# Altium schematic sheet 03-10715_Rev_0.1_Sheet_4.SchDoc
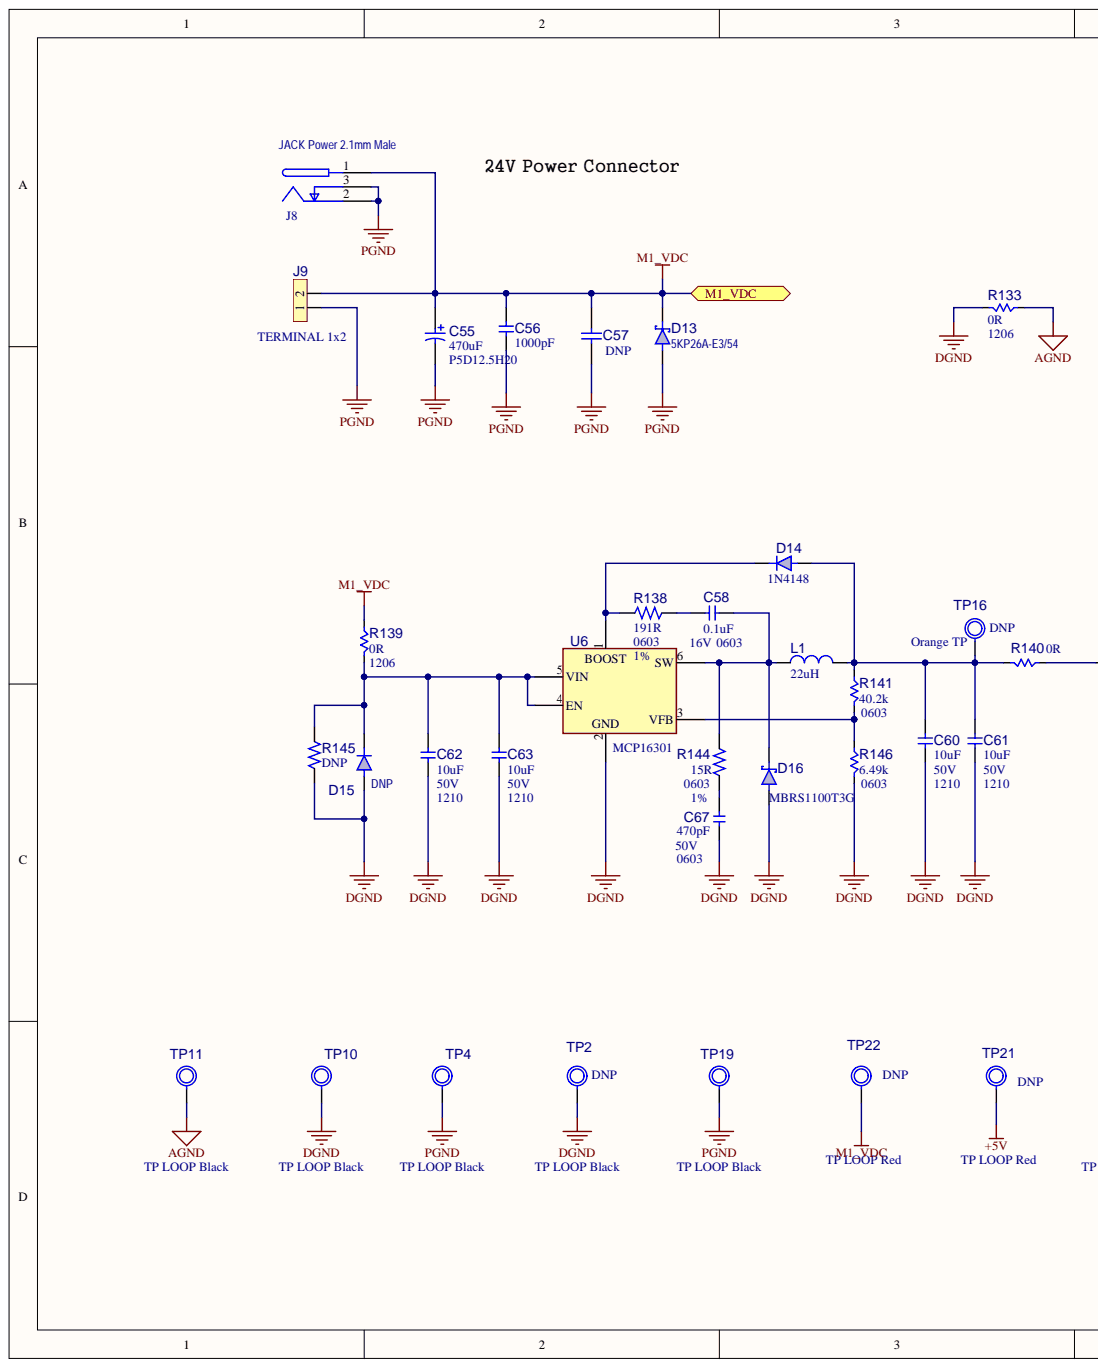
[diagram p0: 03-10715_Rev_0.1_Sheet_4.SchDoc, left]
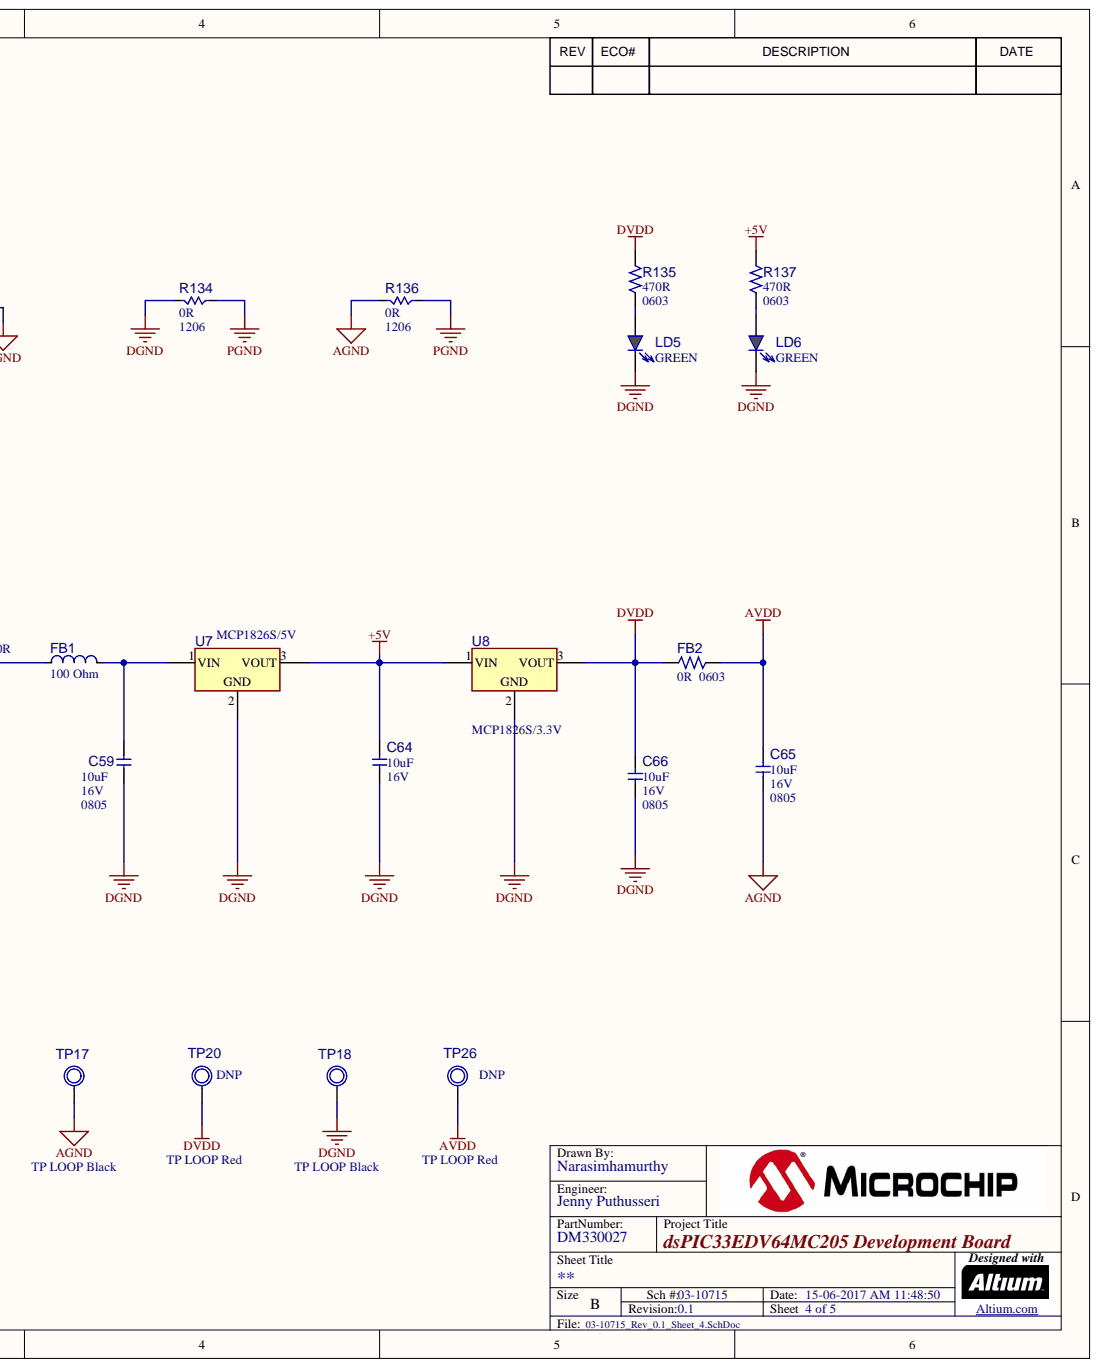
[diagram p1: 03-10715_Rev_0.1_Sheet_4.SchDoc, right]

## Parts
C55  value 470uF  Package: P5D12.5H20  [CAP_RAD_P5D12.5H20]  (hidden comment: Capacitor POL)
C56  value 1000pF  [CAP_C_0603]  (hidden comment: Capacitor NP)
C57  Populated: DNP  [CAP_C_2220]  (hidden comment: Capacitor NP)
C58  value 0.1uF  [CAP_C_0603]  (hidden comment: Capacitor NP)
C59  value 10uF  Package: 0805  Voltage: 16V  [CAP_C_0805]  (hidden comment: Capacitor NP)
C60  value 10uF  Package: 1210  Voltage: 50V  [CAP_C_1210]  (hidden comment: Capacitor NP)
C61  value 10uF  Package: 1210  Voltage: 50V  [CAP_C_1210]  (hidden comment: Capacitor NP)
C62  value 10uF  Package: 1210  Voltage: 50V  [CAP_C_1210]  (hidden comment: Capacitor NP)
C63  value 10uF  Package: 1210  Voltage: 50V  [CAP_C_1210]  (hidden comment: Capacitor NP)
C64  value 10uF  Voltage: 16V  [CAP_C_0805]  (hidden comment: Capacitor NP)
C65  value 10uF  Package: 0805  Voltage: 16V  [CAP_C_0805]  (hidden comment: Capacitor NP)
C66  value 10uF  Package: 0805  Voltage: 16V  [CAP_C_0805]  (hidden comment: Capacitor NP)
C67  value 470pF  Package: 0603  Voltage: 50V  [CAP_C_0603]  (hidden comment: Capacitor NP)
D13  value 5KP26A-E3/54  Manufacturer Part Number 1: 5KP26A-E3/54  [DO-P600, Axial]  (hidden comment: Diode Schottky)
D14  value 1N4148  [SOD-323]  (hidden comment: Diode Single)
D15  Populated: DNP  [DO-214AC_SMA]  (hidden comment: Diode Single)
D16  value MBRS1100T3G  Manufacturer Part Number 1: MBRS1100T3G  [DO-214AA_SMB]  (hidden comment: Diode Schottky)
FB1  value 100 Ohm  [IND_C_0603]  (hidden comment: Inductor)
FB2  value 0R  Package: 0603  [RES_C_0603]  (hidden comment: Resistor)
J8  value JACK Power 2.1mm Male  [CON_JACK_POWER_TH_RA_CUI_PJ-002]  (hidden comment: CON JACK 2C 3P SPST)
J9  value TERMINAL 1x2  [CON_TERMINAL_5.08_1x2_TH_RA_OST_OSTVI022152]  (hidden comment: Header 1x2)
L1  value 22uH  [IND_CFT_XAL6060_L6.56W6.36H6.1]  (hidden comment: Inductor)
LD5  value GREEN  [LED_0603_GREEN]  (hidden comment: LED_green)
LD6  value GREEN  [LED_0603_GREEN]  (hidden comment: LED_green)
R133  value 0R  Package: 1206  [RES_C_1206]  (hidden comment: Resistor)
R134  value 0R  Package: 1206  [RES_C_1206]  (hidden comment: Resistor)
R135  value 470R  Package: 0603  [RES_C_0603]  (hidden comment: Resistor)
R136  value 0R  Package: 1206  [RES_C_1206]  (hidden comment: Resistor)
R137  value 470R  Package: 0603  [RES_C_0603]  (hidden comment: Resistor)
R138  value 191R  [RES_C_0603]  (hidden comment: Resistor)
R139  value 0R  Package: 1206  [RES_C_1206]  (hidden comment: Resistor)
R140  value 0R  [RES_C_0603]  (hidden comment: Resistor)
R141  value 40.2k  Package: 0603  [RES_C_0603]  (hidden comment: RES TKF 40.2k 1% 1/10W SMD 0603)
R144  value 15R  Package: 0603  Tolerance: 1%  [RES_C_0603]  (hidden comment: Resistor)
R145  Populated: DNP  [RES_C_0805]  (hidden comment: Resistor)
R146  value 6.49k  Package: 0603  [RES_C_0603]  (hidden comment: Resistor)
TP2  value TP LOOP Black  Populated: DNP  [CON_TP_TH_V_BLK_KEC_5011]  (hidden comment: Test Point)
TP4  value TP LOOP Black  [CON_TP_TH_V_BLK_KEC_5011]  (hidden comment: Test Point)
TP10  value TP LOOP Black  [CON_TP_TH_V_BLK_KEC_5011]  (hidden comment: Test Point)
TP11  value TP LOOP Black  [CON_TP_TH_V_BLK_KEC_5011]  (hidden comment: Test Point)
TP16  value Orange TP  Populated: DNP  [CON_TP_TH_V_ORN_KEC_5013]  (hidden comment: Test Point)
TP17  value TP LOOP Black  [CON_TP_TH_V_BLK_KEC_5011]  (hidden comment: Test Point)
TP18  value TP LOOP Black  [CON_TP_TH_V_BLK_KEC_5011]  (hidden comment: Test Point)
TP19  value TP LOOP Black  [CON_TP_TH_V_BLK_KEC_5011]  (hidden comment: Test Point)
TP20  value TP LOOP Red  Populated: DNP  [CON_TP_TH_V_RED_KEC_5010]  (hidden comment: Test Point)
TP21  value TP LOOP Red  Populated: DNP  [CON_TP_TH_V_RED_KEC_5010]  (hidden comment: Test Point)
TP22  value TP LOOP Red  Populated: DNP  [CON_TP_TH_V_RED_KEC_5010]  (hidden comment: Test Point)
TP26  value TP LOOP Red  Populated: DNP  [CON_TP_TH_V_RED_KEC_5010]  (hidden comment: Test Point)
U6  value MCP16301  [SOT-23-6]  (hidden comment: MCP16301 SOT-23-6)
U7  value MCP1826S/5V  [SOT-223-3]  (hidden comment: MIC39100 SOT-223-3)
U8  value MCP1826S/3.3V  [SOT-223-3]  (hidden comment: MIC39100 SOT-223-3)

## Ports
M1_VDC

## Nets
+5V: C64.1 R137.1 TP21.1 U7.3 U8.1
AGND: C65.2 R133.2 R136.1 TP11.1 TP17.1
AVDD: C65.1 FB2.2 TP26.1
DGND: C59.2 C60.1 C61.1 C62.1 C63.1 C64.2 C66.2 C67.2 D15.1 D16.1 LD5.2 LD6.2 R133.1 R134.1 R145.1 R146.1 TP2.1 TP10.1 TP18.1 U6.2 U7.2 U8.2
DVDD: C66.1 FB2.1 R135.1 TP20.1 U8.3
PGND: C55.2 C56.1 C57.1 D13.1 J8.2 J8.3 J9.1 R134.2 R136.2 TP4.1 TP19.1
M1_VDC: C55.1 C56.2 C57.2 D13.2 J8.1 J9.2 R139.2 TP22.1 ; port M1_VDC
NetC58_1: C58.1 D16.2 L1.1 R144.2 U6.6(1%_SW)
NetC58_2: C58.2 R138.2
NetC59_1: C59.1 FB1.2 U7.1
NetC60_2: C60.2 C61.2 D14.1 L1.2 R140.1 R141.2 TP16.1
NetC62_2: C62.2 C63.2 D15.2 R139.1 R145.2 U6.4(EN) U6.5(VIN)
NetC67_1: C67.1 R144.1
NetD14_2: D14.2 R138.1 U6.1
NetFB1_1: FB1.1 R140.2
NetLD5_1: LD5.1 R135.2
NetLD6_1: LD6.1 R137.2
NetR141_1: R141.1 R146.2 U6.3(VFB)

## Library data of the parts
C55 = CAP1249: Capacitor; Manufacturer 1: Panasonic Electronic Components; Manufacturer Part Number 1: ECA-1JHG471; Description_: CAP ALU 470uF 63V 20% RAD P5D12.5H20; Dev Tools Status: Production; Owner: Stephen Humberd; Populated: YES; Type: Aluminum; Category: Capacitor; Component Kind: Standard; ComponentLink1Description: Datasheet; ComponentLink1URL: https://chn-vm-apps.microchip.com/mchprepo/parts/Datasheets/ABA0000CE26.pdf; Database Table Name: Capacitor; Library Name: MCHP-CDB.DbLib; MCHP-ID: CAP1249; Quantity Override: 1; Revision: B; Status: MCL Design; Supplier 1: Digi-Key; Supplier Part Number 1: P5585-ND; Symbol: Capacitor POL
C56 = CAP0594: Capacitor; Manufacturer 1: AVX; Manufacturer Part Number 1: 06035A102JAT2A; Description_: CAP CER 1000pF 50V 5% C0G SMD 0603; Populated: Yes; Temperature Coeffcient: C0G; Tolerance: 5%; Type: Ceramic; Voltage: 50V; Category: Capacitor; Component Kind: Standard; ComponentLink1Description: Datasheet; ComponentLink1URL: https://chn-vm-apps.microchip.com/mchprepo/parts/Datasheets/smccp.pdf; Database Table Name: Capacitor; Library Name: MCHP-CDB.DbLib; Manufacturer 2: TDK; Manufacturer Part Number 2: C1608C0G1H102J; MCHP-ID: CAP0594; Operating Temperature: -55C to +125C; Package: 0603; Quantity Override: 1; Revision: 1; Status: Production; Supplier 1: Digi-Key; Supplier 2: Digi-Key; Supplier Part Number 1: 478-1187-2-ND; Supplier Part Number 2: 445-1293-2-ND
C57 = CAP1253: Capacitor; Manufacturer 1: KEMET; Manufacturer Part Number 1: C2220X106K5RACTU; Description_: CAP CER 10uF 50V 10% X7R SMD 2220; Dev Tools Status: Production; Owner: Stephen Humberd; Temperature Coeffcient: X7R; Tolerance: 10%; Type: Ceramic; Value: 10uF; Voltage: 50V; Category: Capacitor; Component Kind: Standard; ComponentLink1Description: Datasheet; ComponentLink1URL: https://chn-vm-apps.microchip.com/mchprepo/parts/Datasheets/KEM_C1013_X7R_FT-CAP_SMD.pdf; Database Table Name: Capacitor; Library Name: MCHP-CDB-Offline.DbLib; MCHP-ID: CAP1253; Operating Temperature: -55°C ~ 125°C; Package: 2220; Quantity Override: 1; Revision: A; Status: MCL Design; Supplier 1: Digi-Key; Supplier Part Number 1: 399-5531-1-ND; Symbol: Capacitor NP
C58 = CAP0011: Capacitor; Manufacturer 1: NIC Components; Manufacturer Part Number 1: NMC0603X7R104K16TRPF; Description_: CAP CER 0.1uF 16V 10% X7R SMD 0603; Populated: Yes; Temperature Coeffcient: X7R; Tolerance: 10%; Type: Ceramic; Voltage: 16V; Category: Capacitor; Component Kind: Standard; ComponentLink1Description: Datasheet; ComponentLink1URL: https://chn-vm-apps.microchip.com/mchprepo/parts/Datasheets/nmc2.pdf; Database Table Name: Capacitor; Library Name: MCHP-CDB.DbLib; Manufacturer 2: Panasonic; Manufacturer 3: Taiyo Yuden; Manufacturer 4: Yageo; Manufacturer 5: Murata; Manufacturer 6: AVX; Manufacturer Part Number 2: ECJ-1VB1C104K; Manufacturer Part Number 3: EMK107B7104KA-T; Manufacturer Part Number 4: CC0603KRX7R7BB104; Manufacturer Part Number 5: GRM188R71C104KA01D; Manufacturer Part Number 6: 0603YC104KAT2A; MCHP-ID: CAP0011; Operating Temperature: -55C to +125C; Package: 0603; Quantity Override: 1; Revision: 3; Status: Production; Supplier 1: NIC Components; Supplier 2: Digi-Key; Supplier 3: Digi-Key; Supplier 4: Newark; Supplier 5: Digi-Key; Supplier 6: Digi-Key; Supplier 7: Digi-Key; Supplier Part Number 1: NMC0603X7R104K16TRPF; Supplier Part Number 2: PCC1762CT-ND; Supplier Part Number 3: 587-1240-2-ND; Supplier Part Number 4: 86H5248; Supplier Part Number 5: 311-1088-1-ND; Supplier Part Number 6: 490-1532-1-ND; Supplier Part Number 7: 478-1239-1-ND
C59 C64-C66 = CAP0373: Capacitor; Manufacturer 1: Murata; Manufacturer Part Number 1: GRM21BR61C106KE15L; Description_: CAP CER 10uF 16V 10% X5R SMD 0805; Populated: Yes; Temperature Coeffcient: X5R; Tolerance: 10%; Type: Ceramic; Category: Capacitor; Component Kind: Standard; ComponentLink1Description: Datasheet; ComponentLink1URL: https://chn-vm-apps.microchip.com/mchprepo/parts/Datasheets/L0005E.pdf; Database Table Name: Capacitor; Library Name: MCHP-CDB.DbLib; Manufacturer 2: Taiyo Yuden; Manufacturer Part Number 2: EMK212BJ106KG-T; MCHP-ID: CAP0373; Operating Temperature: +25C to +125C; Quantity Override: 1; Revision: 1; Status: Production; Supplier 1: Digi-Key; Supplier 2: Digi-Key; Supplier 3: Newark; Supplier Part Number 1: 490-3886-1-ND; Supplier Part Number 2: 587-1295-1-ND; Supplier Part Number 3: 30K5459
  C64: Package: 0805
C60-C63 = CAP1136: Capacitor; Manufacturer 1: TDK; Manufacturer Part Number 1: C3225X7S1H106M; Description_: CAP CER 10uF 50V 20% X7S SMD 1210; Owner: Mihai T(M91442); Populated: Yes; Temperature Coeffcient: X7S; Tolerance: 20%; Type: Ceramic; Category: Capacitor; Component Kind: Standard; ComponentLink1Description: Datasheet; ComponentLink1URL: https://chn-vm-apps.microchip.com/mchprepo/parts/Datasheets/general_B11.pdf; Database Table Name: Capacitor; Library Name: MCHP-CDB.DbLib; MCHP-ID: CAP1136; Operating Temperature: -55C to +125C; Quantity Override: 1; Revision: 0; Status: Production; Supplier 1: Digi-Key; Supplier 2: Farnell; Supplier Part Number 1: 445-4537-1-ND; Supplier Part Number 2: 1844365
C67 = CAP0408: Capacitor; Manufacturer 1: Johanson Dielectrics; Manufacturer Part Number 1: 500R14W471KV4T; Description_: CAP CER 470pF 50V 10% X7R SMD 0603; Populated: Yes; Temperature Coeffcient: X7R; Tolerance: 10%; Type: Ceramic; Category: Capacitor; Component Kind: Standard; ComponentLink1Description: Datasheet; ComponentLink1URL: https://chn-vm-apps.microchip.com/mchprepo/parts/Datasheets/JDI_Surface-Mount_2012-01.pdf; Database Table Name: Capacitor; Library Name: MCHP-CDB.DbLib; Manufacturer 2: Yageo; Manufacturer 3: Murata; Manufacturer Part Number 2: CC0603KRX7R9BB471; Manufacturer Part Number 3: GRM188R71H471KA01D; MCHP-ID: CAP0408; Operating Temperature: -55C to +125C; Quantity Override: 1; Revision: 1; Status: Production; Supplier 1: Digi-Key; Supplier 2: Digi-Key; Supplier 3: Digi-Key; Supplier Part Number 1: 709-1164-1-ND; Supplier Part Number 2: 311-1078-2-ND; Supplier Part Number 3: 490-1489-1-ND
D13 = DIODE1072: Manufacturer 1: Vishay Semiconductor Diodes Division; Description_: TVS 5KW UNIDIR 26V 5% P600; Owner: Stephen Humberd; Populated: YES; Power: 5000W; Reverse Voltage: 26V; Type: Schottky Single; Category: Diode; Component Kind: Standard; ComponentLink1Description: Datasheet; ComponentLink1URL: https://chn-vm-apps.microchip.com/mchprepo/parts/Datasheets/5KP5.0 thru 5KP188A.pdf; Database Table Name: Diode; Library Name: MCHP-CDB.DbLib; MCHP-ID: DIODE1072; Package: P600, Axial; Quantity Override: 1; Revision: A; Status: Design; Supplier 1: Digi-Key; Supplier Part Number 1: 5KP26A-E3/54GICT-ND
D14 = DIODE0096: Manufacturer 1: Diodes Incorporated; Manufacturer Part Number 1: 1N4148WS-7; Description_: DIO RECT 1N4148 855mV 300mA 75V SOD-323; Forward Current: 300mA; Populated: Yes; Power: 200mW; Reverse Current: 50uA; Reverse Voltage: 75V; Type: Rectifier Single; Category: Diode; Component Kind: Standard; ComponentLink1Description: Datasheet; ComponentLink1URL: https://chn-vm-apps.microchip.com/mchprepo/parts/Datasheets/ds30097.pdf; Database Table Name: Diode; Library Name: MCHP-CDB.DbLib; Manufacturer 2: Diodes Incorporated; Manufacturer Part Number 2: 1N4148WS-7-F; MCHP-ID: DIODE0096; Operating Temperature: -65C to +150C; Package: SOD-323; Quantity Override: 1; Revision: 1; Status: Production; Supplier 1: Digi-Key; Supplier 2: Digi-Key; Supplier Part Number 1: 1N4148WSDITR-ND; Supplier Part Number 2: 1N4148WS-FDICT-ND
D15 = DIODE1129: Manufacturer 1: ON Semiconductor; Manufacturer Part Number 1: MRA4005T3G; Description_: DIO RECT MRA4005 1.1V 1A 600V DO-214AC_SMA; Forward Current: 1A; Owner: Bogdan P (M91398); Reverse Current: 10uA; Reverse Voltage: 600V; Type: Rectifier Single; Value: SMA6J28A/CA; Category: Diode; Component Kind: Standard; ComponentLink1Description: Datasheet; ComponentLink1URL: https://chn-vm-apps.microchip.com/mchprepo/parts/Datasheets/MRA4003T3-D.pdf; Database Table Name: Diode; Library Name: MCHP-CDB.DbLib; MCHP-ID: DIODE1129; Operating Temperature: -55C to +175C; Package: DO-214AC_SMA; Quantity Override: 1; Revision: 0; Status: Production; Supplier 1: Digi-Key; Supplier Part Number 1: MRA4005T1GOSCT-ND
D16 = DIODE1076: Manufacturer 1: ON Semiconductor; Description_: DIODE SCHOTTKY 100V 1A SMB; Forward Current: 1A; Owner: Stephen Humberd; Populated: YES; Reverse Voltage: 100V; Type: Schottky Single; Category: Diode; Component Kind: Standard; ComponentLink1Description: Datasheet; ComponentLink1URL: https://chn-vm-apps.microchip.com/mchprepo/parts/Datasheets/MBRS1100T3-D.pdf; Database Table Name: Diode; Library Name: MCHP-CDB.DbLib; MCHP-ID: DIODE1076; Package: SMB; Quantity Override: 1; Reverse Current: 1A; Revision: A; Status: Design; Supplier 1: Digi-Key; Supplier Part Number 1: MBRS1100T3GOSCT-ND
FB1 = IND1123: Manufacturer 1: TDK Corporation; Manufacturer Part Number 1: MPZ1608Y101B; Description_: FERRITE CHIP 100 OHM 2A 0603; Dev Tools Status: Production; Owner: Sompoch Loboonlert; Populated: YES; Type: Ferrite Bead; Category: Inductor; Component Kind: Standard; ComponentLink1Description: Datasheet; ComponentLink1URL: https://chn-vm-apps.microchip.com/mchprepo/parts/Datasheets/beads_commercial_power_mpz_en.pdf; Database Table Name: Inductor; Description: Inductor; Library Name: MCHP-CDB.DbLib; MCHP-ID: IND1123; Package: 0603; Quantity Override: 1; Revision: 1; Status: MCL Design; Supplier 1: Digi-Key; Supplier Part Number 1: 445-2984-1-ND; Symbol: Inductor
FB2 = RSMT0001: Manufacturer 1: Panasonic; Manufacturer Part Number 1: ERJ-3GSY0R00V; Description_: RES TKF 0R 1/10W SMD 0603; Dev Tools Status: Production; Populated: Yes; Power: 1/10W; Type: RES SMD; Category: Resistor; Component Kind: Standard; ComponentLink1Description: SVN Datasheet; ComponentLink1URL: https://chn-vm-apps.microchip.com/mchprepo/parts/Datasheets/nrc.pdf; ComponentLink2Description: Local Datasheet; ComponentLink3Description: Open Symbol; ComponentLink4Description: Open Footprint; ComponentLink5Description: Open Footprint 2; ComponentLink6Description: Open Footprint 3; ComponentLink7Description: Open Footprint 4; Composition: TKF; Database Table Name: Resistor; Description: Resistor; Library Name: MCHP-CDB.DbLib; Manufacturer 2: Panasonic; Manufacturer 3: ROHM; Manufacturer 4: Stackpole Electronics Inc; Manufacturer 5: Stackpole Electronics Inc; Manufacturer 6: Vishay; Manufacturer 7: Yageo; Manufacturer 8: KOA Speer; Manufacturer 9: NIC Components; Manufacturer Part Number 2: ERJ-3GEY0R00V; Manufacturer Part Number 3: MCR03EZPJ000; Manufacturer Part Number 4: RMCF0603ZT0R00; Manufacturer Part Number 5: RMCF 1/16 0 R; Manufacturer Part Number 6: CRCW06030000Z0EA; Manufacturer Part Number 7: RC0603JR-070RL; Manufacturer Part Number 8: RK73Z1JTTP; Manufacturer Part Number 9: NRC06Z0TRF; MCHP-ID: RSMT0001; Operating Temperature: -55C to +155C; Quantity Override: 1; Revision: 2; Status: MCL Design; Supplier 1: Digi-Key; Supplier 2: Digi-Key; Supplier 3: Digi-Key; Supplier 4: Digi-Key; Supplier 5: Digi-Key; Supplier 6: Digi-Key; Supplier 7: Digi-Key; Supplier 8: KOA Speer; Supplier 9: NIC Components; Supplier Part Number 1: P0.0GTR-ND; Supplier Part Number 2: RHM0.0GCT-ND; Supplier Part Number 3: RMCF0603ZT0R00TR-ND; Supplier Part Number 4: RMCF0603ZT0R00CT-ND; Supplier Part Number 5: RMCF1/160RTR-ND; Supplier Part Number 6: 541-0.0GCT-ND; Supplier Part Number 7: 311-0.0GRCT-ND; Supplier Part Number 8: RK73Z1JTTP; Supplier Part Number 9: NRC06Z0TRF; Symbol: Resistor; ComponentLink2URL: C:\ALTIUM_WORK\00001_MCHP-CDB\Datasheets\nrc.pdf; ComponentLink3URL: C:\ALTIUM_WORK\00001_MCHP-CDB\Library\LibraryRefs\Resistor.SchLib; ComponentLink4URL: C:\ALTIUM_WORK\00001_MCHP-CDB\Library\Footprints\RES_C_0603.PcbLib
J8 = CON0195: Manufacturer 1: CUI; Manufacturer Part Number 1: PJ-002A; Current: 2.5A; Database Table Name: Connector; Description_: CON JACK Power 2.1mm 16V 2.5A Male TH R/A; Populated: Yes; Voltage: 16V; Category: Connector; Component Kind: Standard; ComponentLink1Description: Datasheet; ComponentLink1URL: https://chn-vm-apps.microchip.com/mchprepo/parts/Datasheets/PJ-002A.pdf; Library Name: MCHP-CDB.DbLib; MCHP-ID: CON0195; Quantity Override: 1; Revision: 1; Status: Production; Supplier 1: Digi-Key; Supplier Part Number 1: CP-002A-ND; Type: Jack
J9 = CON1220: Manufacturer 1: On Shore Technology Inc; Manufacturer Part Number 1: OSTVI022152; Current: 16A; Database Table Name: Connector; Description_: CON TERMINAL 5.08mm 1x2 Female 12-28AWG 16A TH R/A; Dev Tools Status: Production; Owner: Stephen Humberd; Populated: YES; Voltage: 300V; Category: Connector; Component Kind: Standard; ComponentLink1Description: Datasheet; ComponentLink1URL: https://chn-vm-apps.microchip.com/mchprepo/parts/Datasheets/OSTVIXX2152.pdf; Library Name: MCHP-CDB.DbLib; MCHP-ID: CON1220; Quantity Override: 1; Revision: A; Status: MCL Design; Supplier 1: Digi-Key; Supplier Part Number 1: ED2768-ND; Symbol: Header 1x2; Type: Terminal Block
L1 = IND1122: Manufacturer 1: Coilcraft; Manufacturer Part Number 1: XAL6060-223ME_; DCR: 0.06063R; Description_: INDUCTOR 22uH 5.6A 20% SMD 6.56x6.36x6.1; Owner: Mihai T (M91442); Populated: Yes; RMS Current: 5A; Saturation Current: 5.6A; Test Frequency: 100kHz; Category: Inductor; Component Kind: Standard; ComponentLink1Description: Datasheet; ComponentLink1URL: https://chn-vm-apps.microchip.com/mchprepo/parts/Datasheets/XAL60.pdf; Database Table Name: Inductor; Description: Inductor; Library Name: MCHP-CDB.DbLib; MCHP-ID: IND1122; Operating Temperature: -40C to +125C; Package: SMD_6.56x6.36x6.1; Quantity Override: 1; Revision: 0; Status: Production; Supplier 1: Coilcraft; Supplier Part Number 1: XAL6060-223ME_; Type: Inductor
LD5 LD6 = DIODE1155: LED; Manufacturer 1: Lite-On Inc; Manufacturer Part Number 1: LTST-C191KGKT; Database Table Name: Diode; Description_: DIO LED GREEN 2V 30mA 35mcd Clear SMD 0603; Dev Tools Status: Production; Forward Current: 30mA; Owner: Tad Ahlvers; Populated: YES; Power: 75mW; Reverse Voltage: 5V; Type: LED Single; Category: Diode; Component Kind: Standard; ComponentLink1Description: Datasheet; ComponentLink1URL: https://chn-vm-apps.microchip.com/mchprepo/parts/Datasheets/S_110_LTST-C191KGKT.pdf; Library Name: MCHP-CDB.DbLib; MCHP-ID: DIODE1155; Operating Temperature: -55C to +85C; Quantity Override: 1; Revision: 1; Status: MCL Design; Supplier 1: Digi-Key; Supplier Part Number 1: 160-1446-1-ND; Symbol: LED_green
R133 R134 R136 R139 = RSMT0003: Manufacturer 1: KOA Speer; Manufacturer Part Number 1: RK73Z2BTTD; Current: 2A; Description_: RES TKF 0R SMD 1206; Populated: Yes; Category: Resistor; Component Kind: Standard; ComponentLink1Description: Datasheet; ComponentLink1URL: https://chn-vm-apps.microchip.com/mchprepo/parts/Datasheets/AOA0000CE1.pdf; Composition: TKF; Database Table Name: Resistor; Description: Resistor; Library Name: MCHP-CDB.DbLib; Manufacturer 2: Panasonic; Manufacturer Part Number 2: ERJ-8GEY0R00V; MCHP-ID: RSMT0003; Quantity Override: 1; Revision: 1; Status: Production; Supplier 1: KOA Speer; Supplier 2: Digi-Key; Supplier Part Number 1: RK73Z2BTTD; Supplier Part Number 2: P0.0ETR-ND; Type: RES SMD
R135 R137 = RSMT0518: Resistor Power; Manufacturer 1: Yageo; Manufacturer Part Number 1: RC0603FR-07470RL; Description_: RES TKF 470R 1% 1/10W SMD 0603; Populated: Yes; Power: 1/10W; Temperature Coeffcient: 100ppm/C; Tolerance: 1%; Voltage: 50V; Category: Resistor; Component Kind: Standard; ComponentLink1Description: Datasheet; ComponentLink1URL: https://chn-vm-apps.microchip.com/mchprepo/parts/Datasheets/PYu-RC0603_51_RoHS_L_4.pdf; Composition: TKF; Database Table Name: Resistor; Library Name: MCHP-CDB.DbLib; Manufacturer 2: Panasonic; Manufacturer 3: Stackpole Electronics Inc; Manufacturer Part Number 2: ERJ-3EKF4700V; Manufacturer Part Number 3: RMCF0603FT470R; MCHP-ID: RSMT0518; Operating Temperature: -55C to +155C; Quantity Override: 1; Revision: 1; Status: Production; Supplier 1: Digi-Key; Supplier 2: Digi-Key; Supplier 3: Digi-Key; Supplier Part Number 1: 311-470HRCT-ND; Supplier Part Number 2: P470HCT-ND; Supplier Part Number 3: RMCF1/16470FRTR-ND; Type: RES SMD
R138 = RES1665: Manufacturer 1: Yageo; Manufacturer Part Number 1: RC0603FR-07191RL; Description_: RES TKF 191R 1% 1/10W SMD 0603; Dev Tools Status: Design; Owner: Sam Charles; Populated: YES; Power: 1/10W; Temperature Coeffcient: ±100ppm/°C; Tolerance: 1%; Category: Resistor; Component Kind: Standard; ComponentLink1Description: datasheet; ComponentLink1URL: https://chn-vm-apps.microchip.com/mchprepo/parts/Datasheets/PYu-R_INT-thick_7.pdf; Composition: TKF; Database Table Name: Resistor; Description: Resistor; Library Name: MCHP-CDB-Offline.DbLib; MCHP-ID: RES1665; Operating Temperature: -55°C ~ 155°C; Package: 0603; Quantity Override: 1; Revision: A; Status: MCL Design; Supplier 1: Digi-Key; Supplier Part Number 1: 311-191HRCT-ND; Symbol: RESISTOR; Type: RES SMD
R140 = RSMT0001: Manufacturer 1: NIC Components; Manufacturer Part Number 1: NRC06Z0TRF; Description_: RES TKF 0R 1/10W SMD 0603; Populated: Yes; Power: 1/10W; Category: Resistor; Component Kind: Standard; ComponentLink1Description: Datasheet; ComponentLink1URL: https://chn-vm-apps.microchip.com/mchprepo/parts/Datasheets/nrc.pdf; Composition: TKF; Database Table Name: Resistor; Description: Resistor; Library Name: MCHP-CDB.DbLib; Manufacturer 2: Panasonic; Manufacturer 3: Panasonic; Manufacturer 4: Stackpole Electronics Inc; Manufacturer 5: Vishay; Manufacturer 6: Stackpole Electronics Inc; Manufacturer 7: Yageo; Manufacturer 8: KOA Speer; Manufacturer 9: ROHM; Manufacturer Part Number 2: ERJ-3GSY0R00V; Manufacturer Part Number 3: ERJ-3GEY0R00V; Manufacturer Part Number 4: RMCF0603ZT0R00; Manufacturer Part Number 5: CRCW06030000Z0EA; Manufacturer Part Number 6: RMCF 1/16 0 R; Manufacturer Part Number 7: RC0603JR-070RL; Manufacturer Part Number 8: RK73Z1JTTP; Manufacturer Part Number 9: MCR03EZPJ000; MCHP-ID: RSMT0001; Operating Temperature: -55C to +155C; Package: 0603; Quantity Override: 1; Revision: 2; Status: Production; Supplier 1: NIC Components; Supplier 2: Digi-Key; Supplier 3: Digi-Key; Supplier 4: Digi-Key; Supplier 5: Digi-Key; Supplier 6: Digi-Key; Supplier 7: Digi-Key; Supplier 8: KOA Speer; Supplier 9: Digi-Key; Supplier Part Number 1: NRC06Z0TRF; Supplier Part Number 2: P0.0GTR-ND; Supplier Part Number 3: RMCF0603ZT0R00TR-ND; Supplier Part Number 4: RMCF0603ZT0R00CT-ND; Supplier Part Number 5: 541-0.0GCT-ND; Supplier Part Number 6: RMCF1/160RTR-ND; Supplier Part Number 7: 311-0.0GRCT-ND; Supplier Part Number 8: RK73Z1JTTP; Supplier Part Number 9: RHM0.0GCT-ND; Type: RES SMD
R141 = RES1315: Resistor; Manufacturer 1: Panasonic Electronic Components; Manufacturer Part Number 1: ERJ-3EKF4022V; Circuit Type: Isolated; Description_: RES TKF 40.2k 1% 1/16W SMD 0603; Owner: Stephen Humberd; Populated: YES; Power: 1/16W; Temperature Coeffcient: 100ppm/C; Voltage: 75V; Category: Resistor; Component Kind: Standard; ComponentLink1Description: Datasheet; ComponentLink1URL: https://chn-vm-apps.microchip.com/mchprepo/parts/Datasheets/AOA0000CE2.pdf; Composition: TKF; Database Table Name: Resistor; Library Name: MCHP-CDB.DbLib; MCHP-ID: RES1315; Operating Temperature: -55C to +155C; Quantity Override: 1; Revision: A; Status: Design; Supplier 1: Digi-Key; Supplier Part Number 1: P40.2KHCT-ND; Tolerance: 1%; Type: RES SMD
R144 = RSMT1059: Manufacturer 1: Stackpole Electronics Inc; Manufacturer Part Number 1: RMCF0603FT15R0; Current: 1A; Description_: RES TKF 15R 1% 1/16W SMD 0603; Dev Tools Status: Production; Owner: Sompoch Loboonlert; Populated: Yes; Power: 1/16W; Temperature Coeffcient: 100ppm/C; Voltage: 50V; Category: Resistor; Component Kind: Standard; ComponentLink1Description: Datasheet; ComponentLink1URL: https://chn-vm-apps.microchip.com/mchprepo/parts/Datasheets/SEI-RMCF_RMCP.pdf; Composition: TKF; Database Table Name: Resistor; Description: Resistor; Library Name: MCHP-CDB.DbLib; MCHP-ID: RSMT1059; Operating Temperature: -55C to +155C; Quantity Override: 1; Revision: 1; Status: MCL Design; Supplier 1: Digi-Key; Supplier Part Number 1: RMCF0603FT15R0CT-ND; Symbol: Resistor; Type: RES SMD
R145 = RES1221: Manufacturer 1: Vishay; Manufacturer Part Number 1: CRCW08051K00FKEA; Description_: RES TKF 1k 1% 1/8W SMD 0805; Dev Tools Status: Production; Owner: Mihai T (M91442); Power: 1/8W; Temperature Coeffcient: 100ppm/C; Tolerance: 1%; Value: 1k; Voltage: 150V; Category: Resistor; Component Kind: Standard; ComponentLink1Description: Datasheet; ComponentLink1URL: https://chn-vm-apps.microchip.com/mchprepo/parts/Datasheets/dcrcwe3.pdf; Composition: TKF; Database Table Name: Resistor; Description: Resistor; Library Name: MCHP-CDB.DbLib; MCHP-ID: RES1221; Operating Temperature: -55C to +155C; Package: 0805; Quantity Override: 1; Revision: 0; Status: MCL Design; Supplier 1: Digi-Key; Supplier 2: Farnell; Supplier Part Number 1: 541-1.00KCCT-ND; Supplier Part Number 2: 1469847RL; Symbol: Resistor; Type: RES SMD
R146 = RSMT0320: Manufacturer 1: Panasonic; Manufacturer Part Number 1: ERJ-3EKF6491V; Description_: RES TKF 6.49k 1% 1/16W SMD 0603; Populated: YES; Power: 1/16W; Temperature Coeffcient: 100ppm/C; Tolerance: 1%; Voltage: 75V; Category: Resistor; Component Kind: Standard; ComponentLink1Description: Datasheet; ComponentLink1URL: https://chn-vm-apps.microchip.com/mchprepo/parts/Datasheets/AOA0000CE2.pdf; Composition: TKF; Database Table Name: Resistor; Description: Resistor; Library Name: MCHP-CDB.DbLib; MCHP-ID: RSMT0320; Operating Temperature: -55C to +155C; Quantity Override: 1; Revision: 1; Status: Production; Supplier 1: Digi-Key; Supplier Part Number 1: P6.49KHCT-ND; Type: RES SMD
TP2 = CON1083: Manufacturer 1: Keystone; Manufacturer Part Number 1: 5011; Database Table Name: Connector; Description_: CON TP LOOP Black TH; Owner: Stephen Humberd; Category: Connector; Component Kind: Standard; ComponentLink1Description: Datasheet; ComponentLink1URL: https://chn-vm-apps.microchip.com/mchprepo/parts/Datasheets/5011.pdf; Description: Test Point; Library Name: MCHP-CDB.DbLib; MCHP-ID: CON1083; Quantity Override: 1; Revision: B; Status: Design; Supplier 1: Digi-Key; Supplier Part Number 1: 5011K-ND; Type: Test Point
TP4 TP10 TP11 TP17-TP19 = CON1083: Manufacturer 1: Keystone; Manufacturer Part Number 1: 5011; Database Table Name: Connector; Description_: CON TP LOOP Black TH; Owner: Stephen Humberd; Populated: YES; Category: Connector; Component Kind: Standard; ComponentLink1Description: Datasheet; ComponentLink1URL: https://chn-vm-apps.microchip.com/mchprepo/parts/Datasheets/5011.pdf; Description: Test Point; Library Name: MCHP-CDB.DbLib; MCHP-ID: CON1083; Quantity Override: 1; Revision: B; Status: Design; Supplier 1: Digi-Key; Supplier Part Number 1: 5011K-ND; Type: Test Point
TP16 = CON1085: Manufacturer 1: Keystone; Manufacturer Part Number 1: 5013; Database Table Name: Connector; Description_: CON TP Orange Male TH VERT; Owner: Stephen Humberd; Category: Connector; Component Kind: Standard; ComponentLink1Description: Datasheet; ComponentLink1URL: https://chn-vm-apps.microchip.com/mchprepo/parts/Datasheets/5013.pdf; Description: Test Point; Library Name: MCHP-CDB.DbLib; MCHP-ID: CON1085; Quantity Override: 1; Revision: A; Status: Design; Supplier 1: Digi-Key; Supplier Part Number 1: 5013K-ND; Type: Test Point
TP20-TP22 TP26 = CON1084: Manufacturer 1: Keystone; Manufacturer Part Number 1: 5010; Database Table Name: Connector; Description_: CON TP LOOP Red TH; Owner: Stephen Humberd; Category: Connector; Component Kind: Standard; ComponentLink1Description: Datasheet; ComponentLink1URL: https://chn-vm-apps.microchip.com/mchprepo/parts/Datasheets/5010.pdf; Description: Test Point; Library Name: MCHP-CDB.DbLib; MCHP-ID: CON1084; Quantity Override: 1; Revision: B; Status: Design; Supplier 1: Digi-Key; Supplier Part Number 1: 5010K-ND; Type: Test Point
U6 = MIC0762: DC-DC Convertor; Manufacturer 1: Microchip; Manufacturer Part Number 1: MCP16301T-I/CHY; Accuracy: 2; Adjustable: Yes; ControlPWM: PWM; Database Table Name: MCHP-Analog; Description_: MCHP ANA Switcher MCP16301 SOT-23-6; ExternalSync: No; ForceMinOrderQtyMult: True; InputVoltageRangeMax: 30; InputVoltageRangeMin: 4.0; LeadContent: Pb-FREE; LeadCount: 6; MAPS Description: 30V ASYNCHRONOUS BUCK VOLTAGE REGULATOR; MaxIOUT: 600; MinOrderQty: 5; MPC: V7AA1T6AXA00; OutputCapType: AL / Tant / CER; PackingMedia: T/R; QuiescentCurrent: 2000; SingleDual: Single; Stage: REL; SwitchingFrequency: 500; tblDeviceFamilyID: 2856; TempRange: -40C to +85C; Topology: Buck Regulator; VoutMax: 15; Category: MCHP-Analog; Component Kind: Standard; ComponentLink1Description: Datasheet; ComponentLink1URL: http://www.microchip.com/stellent/idcplg?IdcService=SS_GET_PAGE&nodeId=1335&dDocName=en554058; IntroductionDate: 2011-05-20; Library Name: MCHP-CDB.DbLib; MCHP-ID: MIC0762; PackageType: SOT-23; Populated: Yes; Quantity Override: 1; ResetOutput: No; Revision: 1; SHDN: Yes; Status: Production; Supplier 1: Microchip; Supplier Part Number 1: MCP16301T-I/CHY; Technology: Inductive Switcher; Type: Switcher; UVLO: No; VoutMin: 2
U7 = MIC2768: LDO; Manufacturer 1: Microchip; Manufacturer Part Number 1: MCP1826ST-5002E/DB; Database Table Name: MCHP-Analog; Description_: MCHP ANALOG LDO 5V MCP1826S-5002E/DB SOT-223-3; Dev Tools Status: Production; ForceMinOrderQtyMult: True; LeadContent: Pb-FREE; LeadCount: 3; MAPS Description: 1.0A LDO; MinOrderQty: 50; MPC: GEAJ1YF6XD50; PackingMedia: T/R; Populated: Yes; Stage: REL; tblDeviceFamilyID: 1823; TempRange: -40C to +125C; Category: MCHP-Analog; Component Kind: Standard; ComponentLink1Description: SVN Datasheet; ComponentLink1URL: http://www.microchip.com/wwwproducts/Devices.aspx?product=MCP1826S; ComponentLink2Description: Local Datasheet; ComponentLink3Description: Open Symbol; ComponentLink4Description: Open Footprint; IntroductionDate: 2007-09-05; Library Name: MCHP-CDB.DbLib; Manufacturer 2: Microchip; Manufacturer Part Number 2: MCP1826S-5002E/DB; MCHP-ID: MIC2768; PackageType: SOT-223; Quantity Override: 1; Revision: 0; Status: MCL Design; Supplier 1: Microchip; Supplier 2: Microchip; Supplier Part Number 1: MCP1826ST-5002E/DB; Supplier Part Number 2: MCP1826S-5002E/DB; Symbol: MIC39100 SOT-223-3; Type: LDO; ComponentLink3URL: C:\ALTIUM_WORK\00001_MCHP-CDB\Library\LibraryRefs\MIC39100 SOT-223-3.SchLib; ComponentLink4URL: C:\ALTIUM_WORK\00001_MCHP-CDB\Library\Footprints\SOT-223-3.PcbLib
U8 = MIC1009: LDO; Manufacturer 1: Microchip; Manufacturer Part Number 1: MCP1826ST-3302E/DB; Alarm_Mem: False; Database Table Name: MCHP-Analog; Description_: MCHP ANALOG LDO 3.3V MCP1826S-3302E/DB SOT-223-3; Dev Tools Status: Production; LeadCount: 3; MAPS Description: 1.0A LDO; MPC: GEAJ1YF6XD33; Owner: Aaron VanDuerm; PackingMedia: T/R; Populated: Yes; Symbol: MCP1826S SOT-223-3; tblDeviceFamilyID: 1823; TempRange: -40C to +125C; Brown_Out: False; Category: MCHP-Analog; Chamber_Test: False; Charge_Pump: False; Component Kind: Standard; ComponentLink1Description: Datasheet; ComponentLink1URL: http://www.microchip.com/wwwproducts/Devices.aspx?product=MCP1826S; EncryptAlg: False; Inductive_Boost: False; InputChEnable: False; Interconnect: False; IntroductionDate: 2007-09-05; LED_Driver: False; LFTalkFeture: False; Library Name: MCHP-CDB-Offline.DbLib; Low_Bat: False; Low_Batt: False; ManualReset: False; Manufacturer 2: Microchip; Manufacturer Part Number 2: MCP1826S-3302E/DB; MCHP-ID: MIC1009; PackageType: SOT-223; Power_Good: False; ProgWkUpFilter: False; Quantity Override: 1; RailIn: False; RailOut: False; Rev_Batt_Prot: False; Revision: 2; Sens_Timer: False; Status: MCL Design; Supplier 1: Microchip; Supplier 2: Microchip; Supplier Part Number 1: MCP1826ST-3302E/DB; Supplier Part Number 2: MCP1826S-3302E/DB; Type: LDO; WatchdogTimer: False; WkUpPtnEnable: False
